# Altium schematic sheet FPGA.SchDoc(FPGA)
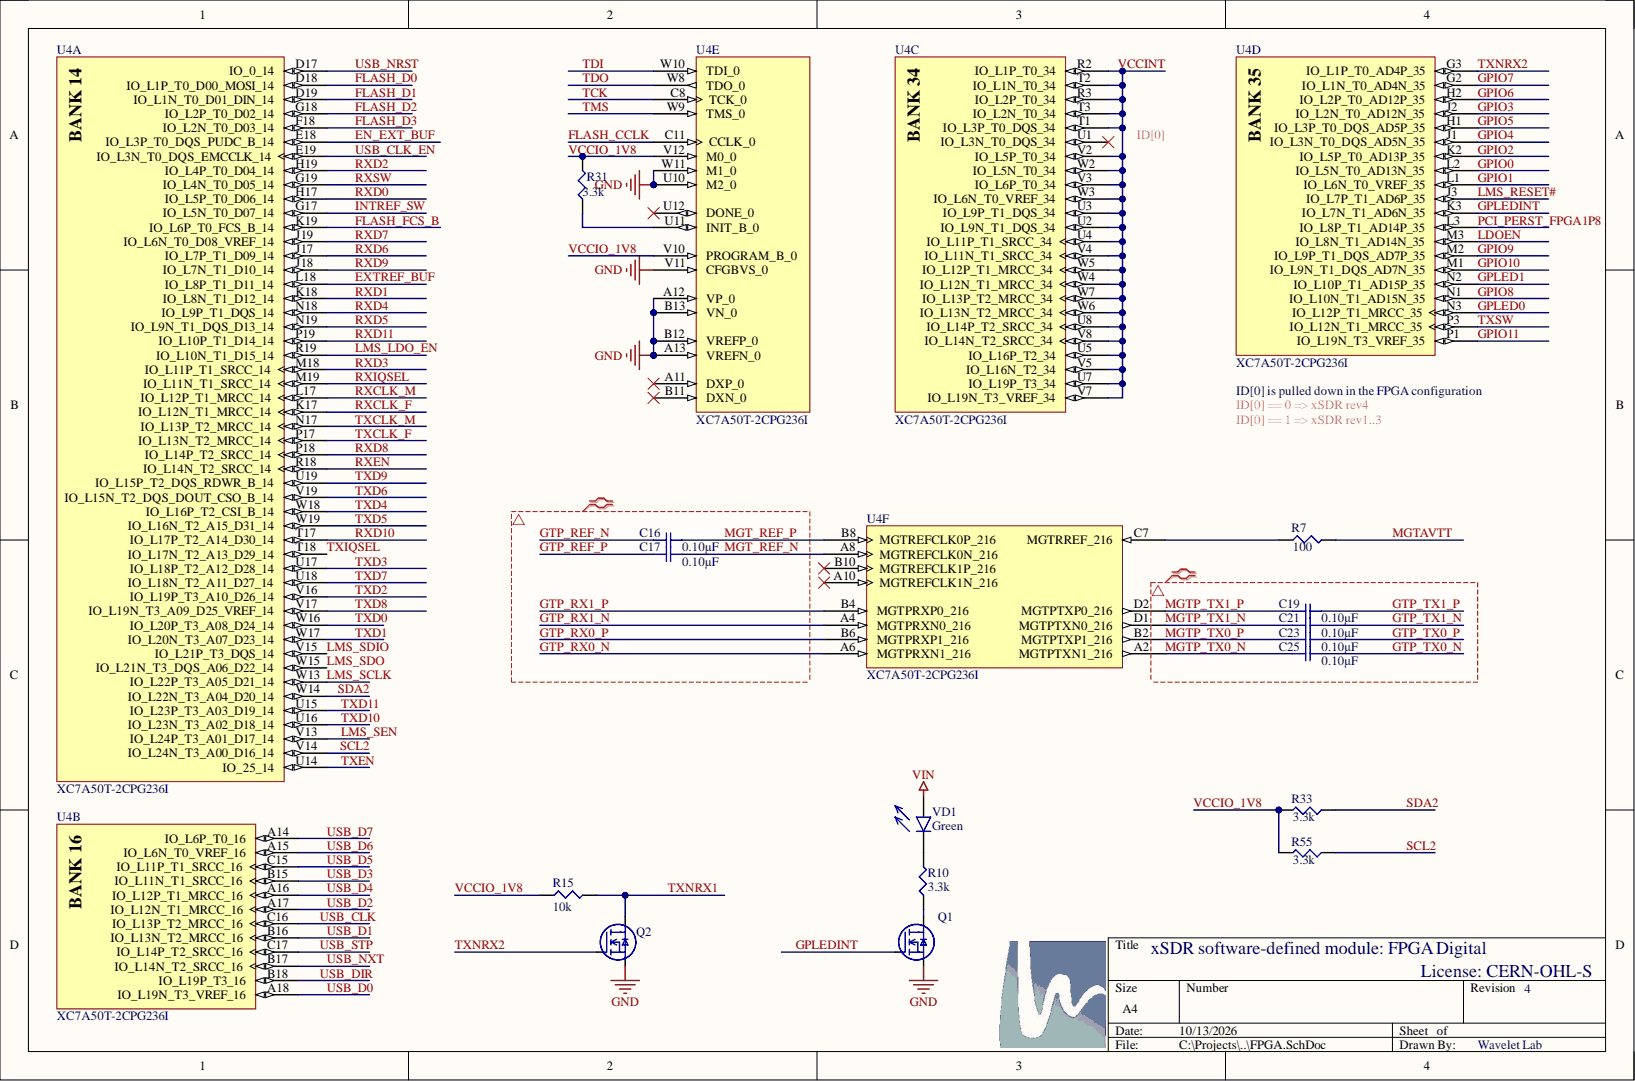
[diagram p0: FPGA.SchDoc]

## Parts
C16  value 0.10µF  [SMD-0201C]
C17  value 0.10µF  [SMD-0201C]
C19  value 0.10µF  [SMD-0201C]
C21  value 0.10µF  [SMD-0201C]
C23  value 0.10µF  [SMD-0201C]
C25  value 0.10µF  [SMD-0201C]
Q1  DMN1260UFA-7B  [DMN1260UFA7B]  (value not drawn)
Q2  DMN1260UFA-7B  [DMN1260UFA7B]  (value not drawn)
R7  value 100  [SMD-0201-RES]
R10  value 3.3k  [SMD-0201-RES]
R15  value 10k  [SMD-0201-RES]
R31  value 3.3k  [SMD-0201-RES]
R33  value 3.3k  [SMD-0201-RES]
R55  value 3.3k  [SMD-0201-RES]
U4  PartNumber: XC7A50T-2CPG236I  [CPG236]  (parts A,B,C,D,E,F)
VD1  Green  [APG0603ZGC-5MAV]

## Nets
GND: Q1.2 Q2.2 U4.A12(VP_0) U4.A13(VREFN_0) U4.B12(VREFP_0) U4.B13(VN_0) U4.U10(M2_0) U4.V11(CFGBVS_0) U4.W11(M1_0)
VIN: VD1.2
EN_EXT_BUF: U4.E18(IO_L3P_T0_DQS_PUDC_B_14)
EXTREF_BUF: U4.L18(IO_L8P_T1_D11_14)
FLASH_CCLK: U4.C11(CCLK_0)
FLASH_D0: U4.D18(IO_L1P_T0_D00_MOSI_14)
FLASH_D1: U4.D19(IO_L1N_T0_D01_DIN_14)
FLASH_D2: U4.G18(IO_L2P_T0_D02_14)
FLASH_D3: U4.F18(IO_L2N_T0_D03_14)
FLASH_FCS_B: U4.K19(IO_L6P_T0_FCS_B_14)
GPIO0: U4.L2(IO_L5N_T0_AD13N_35)
GPIO1: U4.L1(IO_L6N_T0_VREF_35)
GPIO2: U4.K2(IO_L5P_T0_AD13P_35)
GPIO3: U4.J2(IO_L2N_T0_AD12N_35)
GPIO4: U4.J1(IO_L3N_T0_DQS_AD5N_35)
GPIO5: U4.H1(IO_L3P_T0_DQS_AD5P_35)
GPIO6: U4.H2(IO_L2P_T0_AD12P_35)
GPIO7: U4.G2(IO_L1N_T0_AD4N_35)
GPIO8: U4.N1(IO_L10N_T1_AD15N_35)
GPIO9: U4.M2(IO_L9P_T1_DQS_AD7P_35)
GPIO10: U4.M1(IO_L9N_T1_DQS_AD7N_35)
GPIO11: U4.P1(IO_L19N_T3_VREF_35)
GPLED0: U4.N3(IO_L12P_T1_MRCC_35)
GPLED1: U4.N2(IO_L10P_T1_AD15P_35)
GPLEDINT: Q1.1 U4.K3(IO_L7N_T1_AD6N_35)
GTP_REF_N: C16.1
GTP_REF_P: C17.1
GTP_RX0_N: U4.A6(MGTPRXN1_216)
GTP_RX0_P: U4.B6(MGTPRXP1_216)
GTP_RX1_N: U4.A4(MGTPRXN0_216)
GTP_RX1_P: U4.B4(MGTPRXP0_216)
GTP_TX0_N: C25.2
GTP_TX0_P: C23.2
GTP_TX1_N: C21.2
GTP_TX1_P: C19.2
INTREF_SW: U4.G17(IO_L5N_T0_D07_14)
LDOEN: U4.M3(IO_L8N_T1_AD14N_35)
LMS_LDO_EN: U4.R19(IO_L10N_T1_D15_14)
LMS_RESET#: U4.J3(IO_L7P_T1_AD6P_35)
LMS_SCLK: U4.W13(IO_L22P_T3_A05_D21_14)
LMS_SDIO: U4.V15(IO_L21P_T3_DQS_14)
LMS_SDO: U4.W15(IO_L21N_T3_DQS_A06_D22_14)
LMS_SEN: U4.V13(IO_L24P_T3_A01_D17_14)
MGT_REF_N: C17.2 U4.A8(MGTREFCLK0N_216)
MGT_REF_P: C16.2 U4.B8(MGTREFCLK0P_216)
MGTAVTT: R7.2
MGTP_TX0_N: C25.1 U4.A2(MGTPTXN1_216)
MGTP_TX0_P: C23.1 U4.B2(MGTPTXP1_216)
MGTP_TX1_N: C21.1 U4.D1(MGTPTXN0_216)
MGTP_TX1_P: C19.1 U4.D2(MGTPTXP0_216)
PCI_PERST_FPGA1P8: U4.L3(IO_L8P_T1_AD14P_35)
RXCLK_F: U4.K17(IO_L12N_T1_MRCC_14)
RXCLK_M: U4.L17(IO_L12P_T1_MRCC_14)
RXD0: U4.H17(IO_L5P_T0_D06_14)
RXD1: U4.K18(IO_L8N_T1_D12_14)
RXD2: U4.H19(IO_L4P_T0_D04_14)
RXD3: U4.M18(IO_L11P_T1_SRCC_14)
RXD4: U4.N18(IO_L9P_T1_DQS_14)
RXD5: U4.N19(IO_L9N_T1_DQS_D13_14)
RXD6: U4.J17(IO_L7P_T1_D09_14)
RXD7: U4.J19(IO_L6N_T0_D08_VREF_14)
RXD8: U4.P18(IO_L14P_T2_SRCC_14)
RXD9: U4.J18(IO_L7N_T1_D10_14)
RXD10: U4.T17(IO_L17P_T2_A14_D30_14)
RXD11: U4.P19(IO_L10P_T1_D14_14)
RXEN: U4.R18(IO_L14N_T2_SRCC_14)
RXIQSEL: U4.M19(IO_L11N_T1_SRCC_14)
RXSW: U4.G19(IO_L4N_T0_D05_14)
SCL2: R55.1 U4.V14(IO_L24N_T3_A00_D16_14)
SDA2: R33.1 U4.W14(IO_L22N_T3_A04_D20_14)
TCK: U4.C8(TCK_0)
TDI: U4.W10(TDI_0)
TDO: U4.W8(TDO_0)
TMS: U4.W9(TMS_0)
TXCLK_F: U4.P17(IO_L13N_T2_MRCC_14)
TXCLK_M: U4.N17(IO_L13P_T2_MRCC_14)
TXD0: U4.W16(IO_L20P_T3_A08_D24_14)
TXD1: U4.W17(IO_L20N_T3_A07_D23_14)
TXD2: U4.V16(IO_L19P_T3_A10_D26_14)
TXD3: U4.U17(IO_L18P_T2_A12_D28_14)
TXD4: U4.W18(IO_L16P_T2_CSI_B_14)
TXD5: U4.W19(IO_L16N_T2_A15_D31_14)
TXD6: U4.V19(IO_L15N_T2_DQS_DOUT_CSO_B_14)
TXD7: U4.U18(IO_L18N_T2_A11_D27_14)
TXD8: U4.V17(IO_L19N_T3_A09_D25_VREF_14)
TXD9: U4.U19(IO_L15P_T2_DQS_RDWR_B_14)
TXD10: U4.U16(IO_L23N_T3_A02_D18_14)
TXD11: U4.U15(IO_L23P_T3_A03_D19_14)
TXEN: U4.U14(IO_25_14)
TXIQSEL: U4.T18(IO_L17N_T2_A13_D29_14)
TXNRX1: Q2.3 R15.2
TXNRX2: Q2.1 U4.G3(IO_L1P_T0_AD4P_35)
TXSW: U4.P3(IO_L12N_T1_MRCC_35)
USB_CLK: U4.C16(IO_L13P_T2_MRCC_16)
USB_CLK_EN: U4.E19(IO_L3N_T0_DQS_EMCCLK_14)
USB_D0: U4.A18(IO_L19N_T3_VREF_16)
USB_D1: U4.B16(IO_L13N_T2_MRCC_16)
USB_D2: U4.A17(IO_L12N_T1_MRCC_16)
USB_D3: U4.B15(IO_L11N_T1_SRCC_16)
USB_D4: U4.A16(IO_L12P_T1_MRCC_16)
USB_D5: U4.C15(IO_L11P_T1_SRCC_16)
USB_D6: U4.A15(IO_L6N_T0_VREF_16)
USB_D7: U4.A14(IO_L6P_T0_16)
USB_DIR: U4.B18(IO_L19P_T3_16)
USB_NRST: U4.D17(IO_0_14)
USB_NXT: U4.B17(IO_L14N_T2_SRCC_16)
USB_STP: U4.C17(IO_L14P_T2_SRCC_16)
VCCINT: U4.R2(IO_L1P_T0_34) U4.R3(IO_L2P_T0_34) U4.T1(IO_L3P_T0_DQS_34) U4.T2(IO_L1N_T0_34) U4.T3(IO_L2N_T0_34) U4.U2(IO_L9N_T1_DQS_34) U4.U3(IO_L9P_T1_DQS_34) U4.U4(IO_L11P_T1_SRCC_34) U4.U5(IO_L16P_T2_34) U4.U7(IO_L19P_T3_34) U4.U8(IO_L14P_T2_SRCC_34) U4.V2(IO_L5P_T0_34) U4.V3(IO_L6P_T0_34) U4.V4(IO_L11N_T1_SRCC_34) U4.V5(IO_L16N_T2_34) U4.V7(IO_L19N_T3_VREF_34) U4.V8(IO_L14N_T2_SRCC_34) U4.W2(IO_L5N_T0_34) U4.W3(IO_L6N_T0_VREF_34) U4.W4(IO_L12N_T1_MRCC_34) U4.W5(IO_L12P_T1_MRCC_34) U4.W6(IO_L13N_T2_MRCC_34) U4.W7(IO_L13P_T2_MRCC_34)
VCCIO_1V8: R15.1 R31.2 R33.2 R55.2 U4.V10(PROGRAM_B_0) U4.V12(M0_0)
NetQ1_3: Q1.3 R10.1
NetR7_1: R7.1 U4.C7(MGTRREF_216)
NetR10_2: R10.2 VD1.1
NetR31_1: R31.1 U4.U11(INIT_B_0)

Not connected: U4 A10(MGTREFCLK1N_216) A11(DXP_0) B10(MGTREFCLK1P_216) B11(DXN_0) U1(IO_L3N_T0_DQS_34) U12(DONE_0)

## Library data of the parts
C16 C17 C19 C21 C23 C25 = GRM033R60J104ME19D: CAP CER 0.1UF 6.3V X5R 0201; Manufacturer: Murata Electronics North America; @ qty: 0; Minimum Quantity: 1; Tolerance: ±20%; Unit Price (USD): 0.1; Applications: General Purpose; Component Kind: Standard; Datasheets: http://www.murata.com/~/media/webrenewal/support/library/catalog/products/capacitor/mlcc/c02e.pdf; Digi-Key Part Number: 490-5404-1-ND; Factory Stock: 0; Failure Rate: Surface Mount, MLCC; Features: -; Height - Seated (Max): 0.013" (0.33mm); Image: //media.digikey.com/Renders/Murata%20Renders/ZRB-SERIES-0402.jpg; Lead Spacing: -; Mounting Type: 0201 (0603 Metric); Operating Temperature: -55°C ~ 85°C; Package / Case: 0.024" L x 0.012" W (0.60mm x 0.30mm); Packaging: Cut Tape (CT); Part Number: GRM033R60J104ME19D; Part Status: Active; Quantity Available: 381529; Ratings: -; Series: GRM; Size / Dimension: -; Temperature Coefficient: X5R; Thickness (Max): -; Voltage: 6.3V; Library Name: USDR.IntLib
Q1 Q2 = DMN1260UFA-7B: MOSFET N-Ch 12V  Enh FET 8 VGS 60pF 0.92nC; Manufacturer: Diodes Incorporated; VGS thrs max: 2.5V; Component Kind: Standard; JLCPCBPN: C6225387; Library Name: Diode_Transistor.IntLib; Value: DMN1260UFA-7B
R7 = ERJ-1GEJ101C: RES SMD 100 OHM 5% 1/20W 0201; Manufacturer: Panasonic Electronic Components; @ qty: 1500; Factory Stock: 0; Minimum Quantity: 1; Power (Watts): 0.05W, 1/20W; Temperature Coefficient: ±200ppm/°C; Unit Price (USD): 0.00787; Component Kind: Standard; Composition: Thick Film; Datasheets: //media.digikey.com/pdf/Data%20Sheets/Panasonic%20Resistors%20Thermistors%20PDFs/ERJ%205%25.pdf; Digi-Key Part Number: P100AGCT-ND; Failure Rate: -; Features: -; Height - Seated (Max): 0.010" (0.26mm); Image: //media.digikey.com/Renders/Panasonic%20Renders/ERJ-0201%20Pkg.jpg; Number of Terminations: 2; Operating Temperature: -55°C ~ 125°C; Package / Case: 0201 (0603 Metric); Packaging: Cut Tape (CT); Part Number: ERJ-1GEJ101C; Part Status: Active; Quantity Available: 115724; Series: ERJ; Size / Dimension: 0.024" L x 0.012" W (0.60mm x 0.30mm); Supplier Device Package: 0201; Tolerance: ±5%; Library Name: USDR.IntLib
R10 R31 R33 R55 = ERJ-1GEJ332C: RES SMD 3.3K OHM 5% 1/20W 0201; Manufacturer: Panasonic Electronic Components; @ qty: 1500; Factory Stock: 0; Minimum Quantity: 1; Power (Watts): 0.05W, 1/20W; Temperature Coefficient: ±200ppm/°C; Unit Price (USD): 0.00787; Component Kind: Standard; Composition: Thick Film; Datasheets: //media.digikey.com/pdf/Data%20Sheets/Panasonic%20Resistors%20Thermistors%20PDFs/ERJ%205%25.pdf; Digi-Key Part Number: P3.3KAGCT-ND; Failure Rate: -; Features: -; Height - Seated (Max): 0.010" (0.26mm); Image: //media.digikey.com/Renders/Panasonic%20Renders/ERJ-0201%20Pkg.jpg; Number of Terminations: 2; Operating Temperature: -55°C ~ 125°C; Package / Case: 0201 (0603 Metric); Packaging: Cut Tape (CT); Part Number: ERJ-1GEJ332C; Part Status: Active; Quantity Available: 45455; Series: ERJ; Size / Dimension: 0.024" L x 0.012" W (0.60mm x 0.30mm); Supplier Device Package: 0201; Tolerance: ±5%; Library Name: USDR.IntLib
R15 = ERJ-1GEJ332C: RES SMD 3.3K OHM 5% 1/20W 0201; Manufacturer: Panasonic Electronic Components; Part Number: ERJ-1GEJ103C; @ qty: 1500; Factory Stock: 0; Minimum Quantity: 1; Power (Watts): 0.05W, 1/20W; Temperature Coefficient: ±200ppm/°C; Unit Price (USD): 0.00787; Component Kind: Standard; Composition: Thick Film; Datasheets: //media.digikey.com/pdf/Data%20Sheets/Panasonic%20Resistors%20Thermistors%20PDFs/ERJ%205%25.pdf; Digi-Key Part Number: P10KAGCT-ND; Failure Rate: -; Features: -; Height - Seated (Max): 0.010" (0.26mm); Image: //media.digikey.com/Renders/Panasonic%20Renders/ERJ-0201%20Pkg.jpg; Number of Terminations: 2; Operating Temperature: -55°C ~ 125°C; Package / Case: 0201 (0603 Metric); Packaging: Cut Tape (CT); Part Status: Active; Quantity Available: 45455; Series: ERJ; Size / Dimension: 0.024" L x 0.012" W (0.60mm x 0.30mm); Supplier Device Package: 0201; Tolerance: ±5%; Library Name: USDR.IntLib
U4 = XC7A35T-2CPG236C: Artix-7 FPGA; Manufacturer: Xilinx; Available User I/O: 106; Clock Mgmt Tiles (CMTs): 5; GTP: 2; Logic Cells: 33280; Total Block RAM: 1800 Kb; Component Kind: Standard; ComponentLink1Description: Manufacturer URL; ComponentLink1URL: http://www.xilinx.com/; ComponentLink2Description: Datasheet; ComponentLink2URL: http://www.xilinx.com/support/documentation/data_sheets/ds180_7Series_Overview.pdf; ComponentLink3Description: Package Specification; ComponentLink3URL: http://www.xilinx.com/support/documentation/user_guides/ug475_7Series_Pkg_Pinout.pdf; ComponentLink4Description: DC and Switching Characteristics; ComponentLink4URL: http://www.xilinx.com/support/documentation/data_sheets/ds181_Artix_7_Data_Sheet.pdf; ComponentLink5Description: Package File; ComponentLink5URL: http://www.xilinx.com/support/packagefiles/a7packages/xc7a200tsbg484pkg.txt; ComponentLink6Description: Packaging and Pinout; ComponentLink6URL: http://www.xilinx.com/support/documentation/user_guides/ug475_7Series_Pkg_Pinout.pdf; DatasheetVersion: v1.15; Maximum Distributed RAM: 400 Kb; Mounting Technology: Surface Mount; NexusDeviceID: XC7A35T-2CPG236C; Operating Temperature Range: Commercial; PackageDescription: 238-Ball Wire-Bond Chip-Scale BGA, Body 10 x10 mm, Pitch 0.5 mm; PackageReference: CPG-236; PackageVersion: Rev. 1.11; Speed Grades: 2; Library Name: USDR.IntLib
VD1 = APG0603ZGC-5MAV: LED Green SMD; Manufacturer: Kingbright; Component Kind: Standard; Datasheets: https://www.mouser.com/datasheet/2/216/APG0603ZGC_5MAV-1994294.pdf; Height - Seated (Max): 0.008" (0.2mm); Operating Temperature: -55°C ~ 125°C; Part Number: APG0603ZGC-5MAV; Size / Dimension: 0.026" L x 0.014" W (0.65mm x 0.35mm); Value: Green; Library Name: USDR.IntLib
